# Altium schematic sheet gun schematic.SchDoc
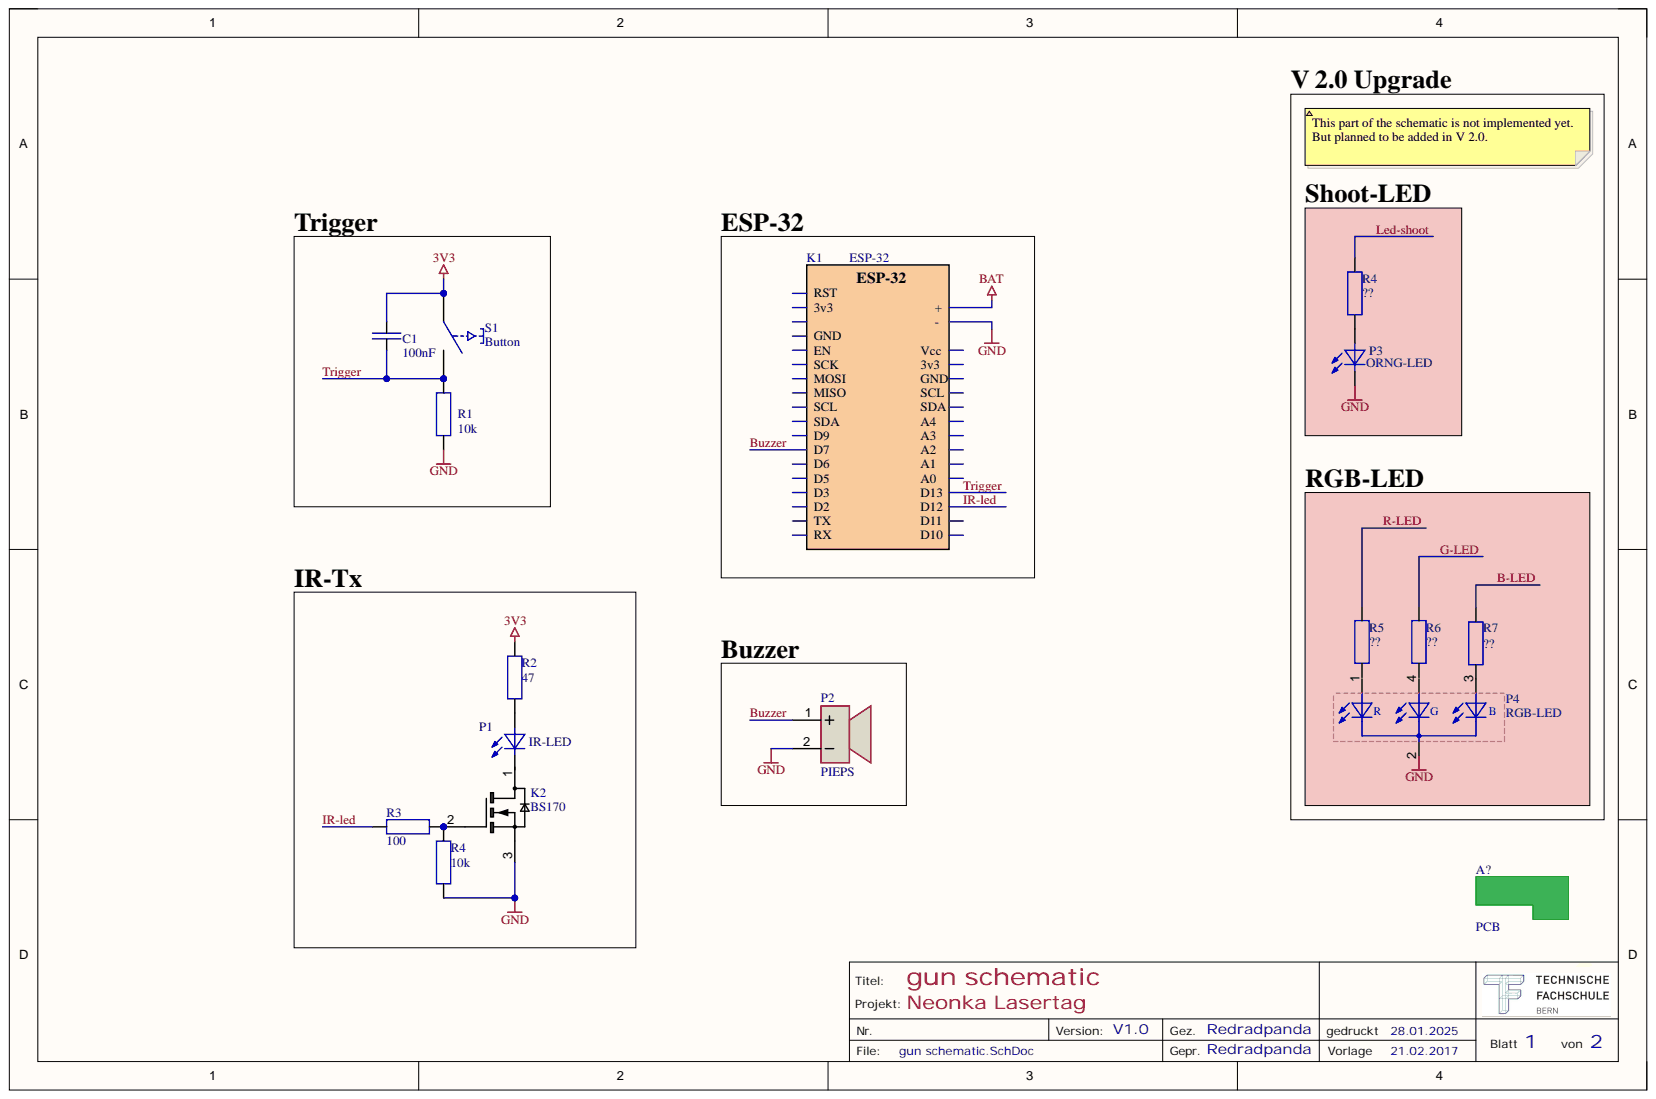
[diagram p0: gun schematic.SchDoc]

## Parts
A?  PCB  (mechanical)
C1  100nF  [RADIAL-CAP_DI2-1PI1]
K2  BS170  [TO92]
P1  IR-LED  [LED-5MM_L]
P2  PIEPS  [PKM13EPYH4002-B0]
P3  ORNG-LED  [LED-5MM_S]
P4  RGB-LED  [LED-RGB-5MM_S]
R1  10k  [AXIAL-RESISTOR_DI4-1]
R2  47  [0805_R]
R3  100  [0805_R]
R4  ??  [AXIAL-RESISTOR_DI4-1]
R4  10k  [0805_R]
R5  ??  [AXIAL-RESISTOR_DI4-1]
R6  ??  [AXIAL-RESISTOR_DI4-1]
R7  ??  [AXIAL-RESISTOR_DI4-1]
S1  Button

## Nets
3V3: C1.2 R2.2 S1.C
GND: K2.3 P2.2 P3.K P4.2 R1.1 R4.1
B-LED: R7.2
Buzzer: P2.1
G-LED: R6.2
IR-led: R3.2
Led-shoot: R4.2
R-LED: R5.2
Trigger: C1.1 R1.2 S1.NO
NetK2_2: K2.2 R3.1 R4.2
NetP1_A: P1.A R2.1
NetP3_A: P3.A R4.1
NetP4_3: P4.3 R7.1
BAT:

Not connected: K2 1; P1 K; P4 1 4; R5 1; R6 1

## Library data of the parts
A? = A-PCB: Zusatz: intern gefertigtes PCB; Description: PCB; E-Preis: ?; Library Name: TFBern.SchLib
C1 = C-CAP_THT: Schichtkondensator; intBestellnummer: div.; intLieferant: TF Bern; Zusatz: Kondensator.html; Component Kind: Standard; Library Name: TFBern.SchLib
K2 = K-FET_BS170: NMOSFET, 60V, 0.5A, 5Ohm; extBestellnummer: 512-BS170; extLieferant: Mouser; Hersteller: General Semiconductor; intBestellnummer: V 54 0302; intLieferant: TF Bern; Zusatz: N-Kanal-MOSFET, Verarmungstyp; Component Kind: Standard; Library Name: TFBern.SchLib; Typ: BS170
P1 P3 = P-LED_THT: LED THT generisch; intBestellnummer: div.; Zusatz: DiodenLED.html; Component Kind: Standard; extBestellnummer: -; extLieferant: -; Hersteller: -; intLieferant: -; Library Name: TFBern.SchLib; Typ: -
P2 = P-BUZZER: Buzzer, Piepser; intLieferant: LOHU4; Component Kind: Standard; Library Name: TFBern.SchLib
P4 = P-LED-RGB_THT_klar: L-154A4SURKQBDZGW; extBestellnummer: 2290374; extLieferant: Farnell; Hersteller: Kingbright; intLieferant: LOHU4; Zusatz: auf BAM-Light; Component Kind: Standard; intBestellnummer: -; Library Name: TFBern.SchLib; Typ: L-154A4SURKQBDZGW
R1 R4-R7 = R-RES_THT: Widerstand THT generisch; intBestellnummer: div.; intLieferant: TF Bern; Zusatz: Widerstand.html; Component Kind: Standard; Library Name: TFBern.SchLib
R2-R4 = R-RES_SMD: Widerstand SMD generisch; intBestellnummer: div.; intLieferant: TF Bern; Zusatz: Widerstand.html; Component Kind: Standard; Library Name: TFBern.SchLib
S1 = S-TASTER-T1SK: Taste OFF-(ON) 1-polig; intBestellnummer: div.; intLieferant: TF Bern; Zusatz: SchalterTaster.html; Component Kind: Standard; Library Name: TFBern.SchLib
